# Altium schematic sheet PestAlert.SchDoc
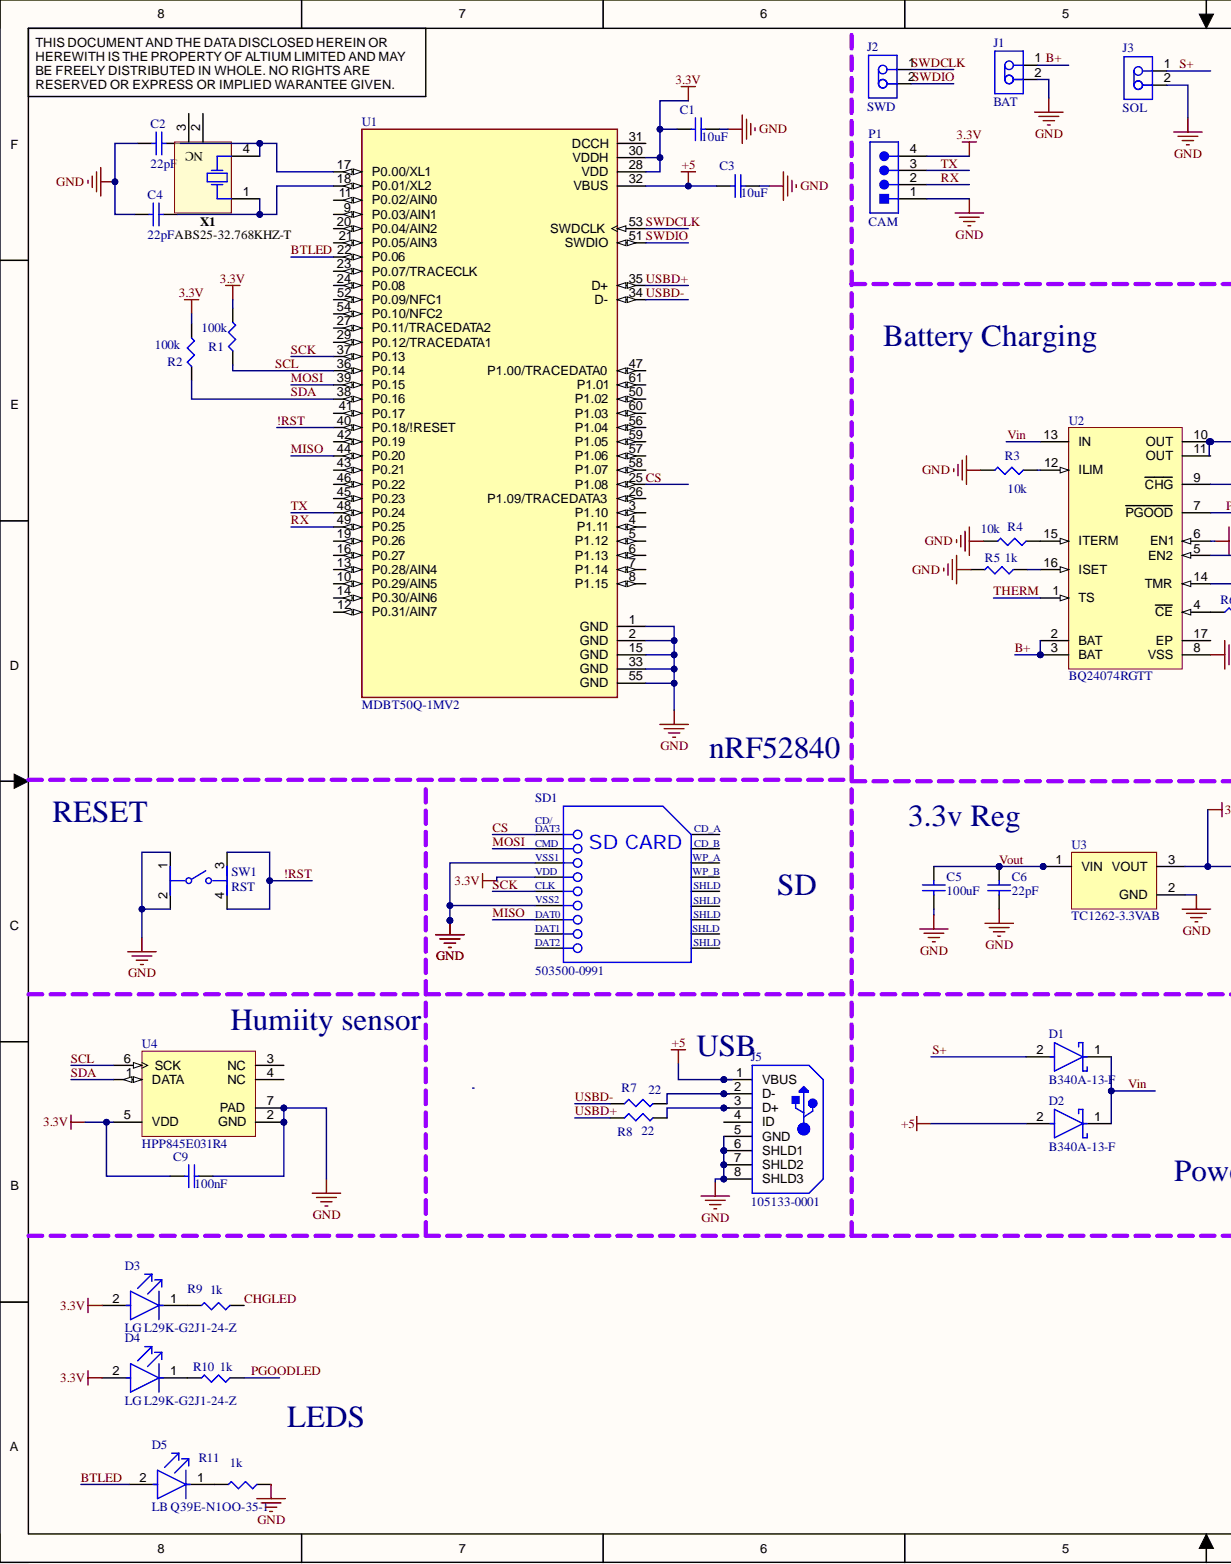
[diagram p0: PestAlert.SchDoc, left]
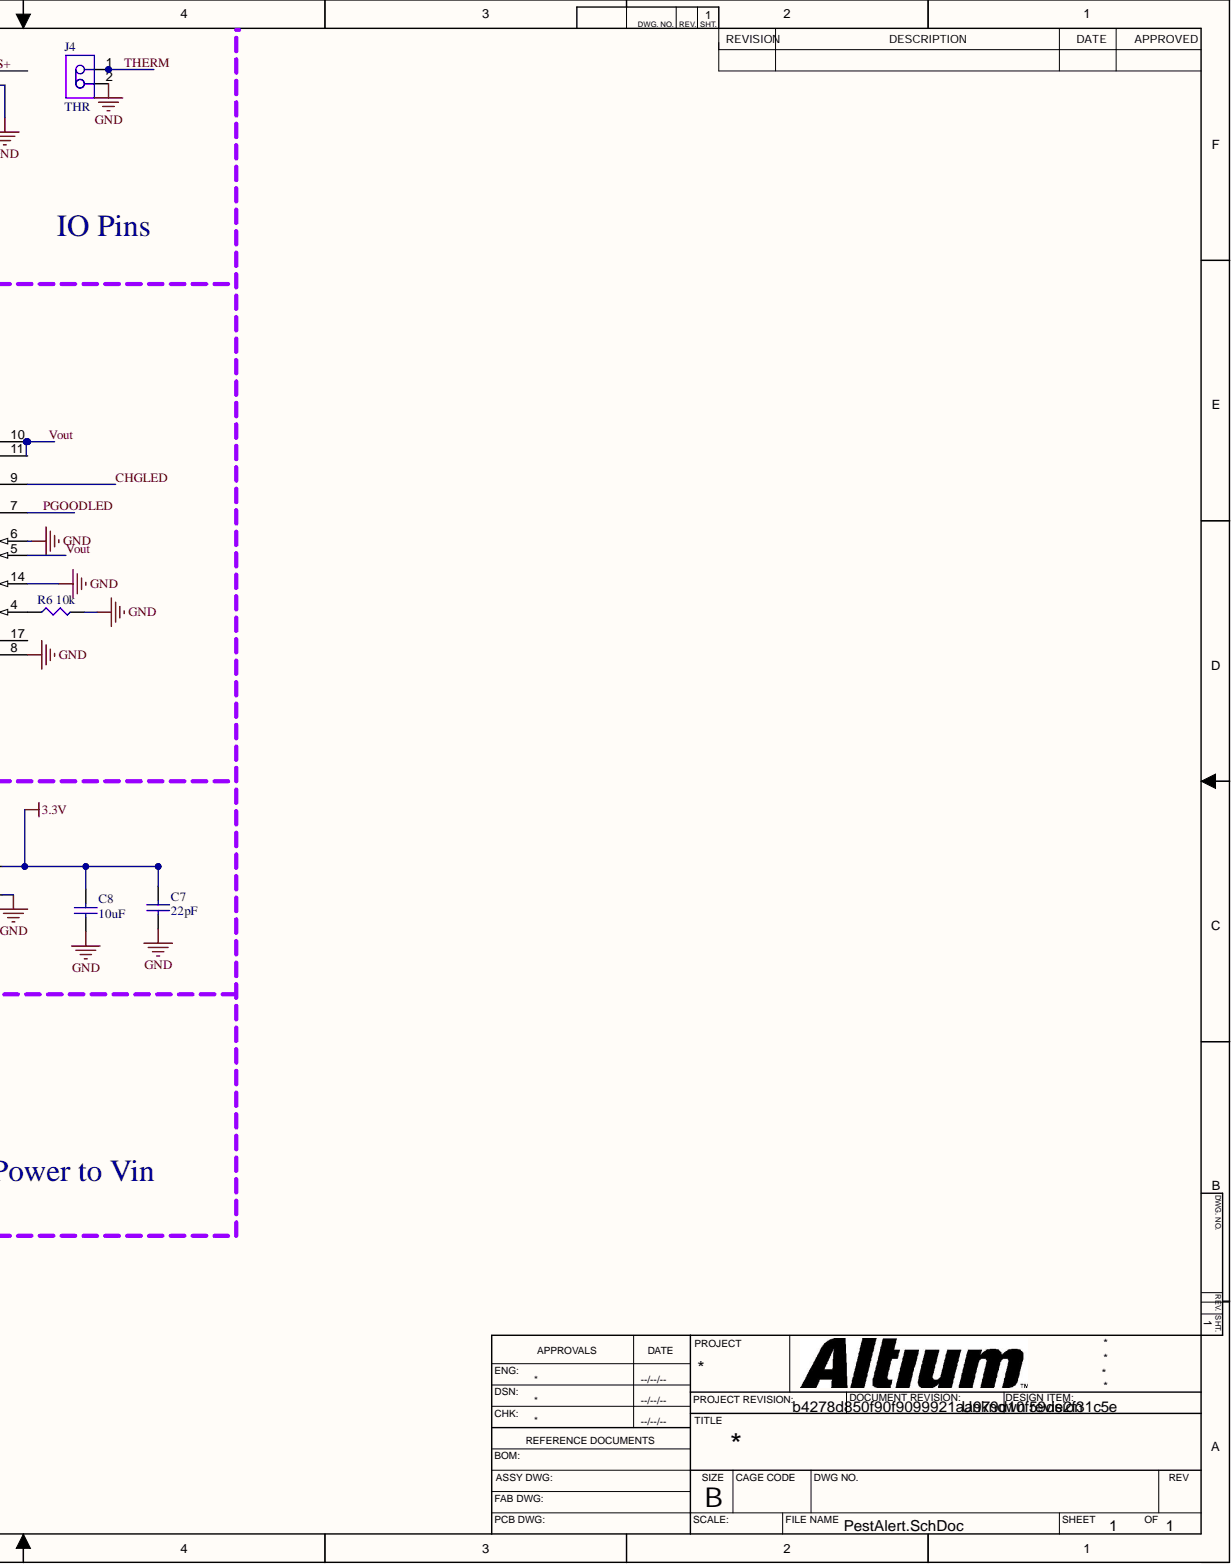
[diagram p1: PestAlert.SchDoc, right]

## Parts
C1  value 10uF  [C1206]  (hidden comment: Cap Semi)
C2  value 22pF  [C1206]  (hidden comment: Cap Semi)
C3  value 10uF  [C1206]  (hidden comment: Cap Semi)
C4  value 22pF  [C1206]  (hidden comment: Cap Semi)
C5  value 100uF  [C1206]  (hidden comment: Cap Semi)
C6  value 22pF  [C1206]  (hidden comment: Cap Semi)
C7  value 22pF  [C1206]  (hidden comment: Cap Semi)
C8  value 10uF  [C1206]  (hidden comment: Cap Semi)
C9  value 100nF  [C1206]  (hidden comment: Cap Semi)
D1  B340A-13-F  [FP-SMA-MFG]
D2  B340A-13-F  [FP-SMA-MFG]
D3  LG L29K-G2J1-24-Z  [FP-LG_L29K-MFG]
D4  LG L29K-G2J1-24-Z  [FP-LG_L29K-MFG]
D5  LB Q39E-N1OO-35-1  [FP-LB_Q39E-MFG]
J1  BAT  [JST-B2B-PH-K-S_V]
J2  SWD  [JST-B2B-PH-K-S_V]
J3  SOL  [JST-B2B-PH-K-S_V]
J4  THR  [JST-B2B-PH-K-S_V]
J5  105133-0001  Standard: USB  [MOLX-105133-0001_V]
P1  CAM  [JST-B4B-PH-K-S_V]
R1  100k  [SMD-1206-RES]
R2  100k  [SMD-1206-RES]
R3  10k  [SMD-1206-RES]
R4  10k  [SMD-1206-RES]
R5  1k  [SMD-1206-RES]
R6  10k  [SMD-1206-RES]
R7  22  [SMD-1206-RES]
R8  22  [SMD-1206-RES]
R9  1k  [SMD-1206-RES]
R10  1k  [SMD-1206-RES]
R11  1k  [SMD-1206-RES]
SD1  503500-0991  [MOLX-503500-0991_V]
SW1  RST  [FP-1825910-2-0]
U1  MDBT50Q-1MV2  [XCVR_MDBT50Q-1MV2]
U2  BQ24074RGTT  [RGT0016C_V]
U3  TC1262-3.3VAB  [TO-220-AB3]
U4  HPP845E031R4  [TECO-SON-6_V]
X1  ABS25-32.768KHZ-T  [ABRA-ABS25-4_V]

## Nets
3.3V: C1.1 C7.1 C8.1 C9.1 D3.2 D4.2 P1.4 R1.2 R2.2 SD1.4 U1.28(VDD) U1.30(VDDH) U3.3 U4.5(VDD)
+5: C3.1 D2.2 J5.1(VBUS) U1.32(VBUS)
GND: C1.2 C2.2 C3.2 C4.2 C5.2 C6.2 C7.2 C8.2 C9.2 J1.2 J3.2 J4.2 J5.5(GND) J5.6(SHLD1) J5.7(SHLD2) J5.8(SHLD3) P1.1 R3.2 R4.2 R5.2 R6.2 R11.1 SD1.3 SD1.6 SW1.1 SW1.2 U1.1(GND) U1.2(GND) U1.15(GND) U1.33(GND) U1.55(GND) U2.6(EN1) U2.8(VSS) U2.14(TMR) U3.2 U4.2(GND) U4.7(PAD)
!RST: SW1.3 SW1.4 U1.40(P0.18/!RESET)
B+: J1.1 U2.2(BAT) U2.3(BAT)
BTLED: D5.2 U1.22(P0.06)
CHGLED: R9.1 U2.9(CHG)
CS: SD1.1 U1.25(P1.08)
MISO: SD1.7 U1.44(P0.20)
MOSI: SD1.2(SD) U1.39(P0.15)
PGOODLED: R10.1 U2.7(PGOOD)
RX: P1.2 U1.49(P0.25)
S+: D1.2 J3.1
SCK: SD1.5 U1.37(P0.13)
SCL: R1.1 U1.36(P0.14) U4.6(SCK)
SDA: R2.1 U1.38(P0.16) U4.1(DATA)
SWDCLK: J2.1 U1.53(SWDCLK)
SWDIO: J2.2 U1.51(SWDIO)
THERM: J4.1 U2.1(TS)
TX: P1.3 U1.48(P0.24)
USBD+: R8.1 U1.35(D+)
USBD-: R7.1 U1.34(D-)
Vin: D1.1 D2.1 U2.13(IN)
Vout: C5.1 C6.1 U2.5(EN2) U2.10(OUT) U3.1
NetC2_1: C2.1 U1.17(P0.00/XL1) X1.4
NetC4_1: C4.1 U1.18(P0.01/XL2) X1.1
NetD3_1: D3.1 R9.2
NetD4_1: D4.1 R10.2
NetD5_1: D5.1 R11.2
NetJ5_2: J5.2(D-) R7.2
NetJ5_3: J5.3(D+) R8.2
NetR3_1: R3.1 U2.12(ILIM)
NetR4_1: R4.1 U2.15(ITERM)
NetR5_1: R5.1 U2.16(ISET)
NetR6_1: R6.1 U2.4(CE)

Not connected: J5 4(ID); SD1 8 9 10 11(CARD) 12 13 14 15 16 17 18; U1 3(P1.10) 4(P1.11) 5(P1.12) 6(P1.13) 7(P1.14) 8(P1.15) 9(P0.03/AIN1) 10(P0.29/AIN5) 11(P0.02/AIN0) 12(P0.31/AIN7) 13(P0.28/AIN4) 14(P0.30/AIN6) 16(P0.27) 19(P0.26) 20(P0.04/AIN2) 21(P0.05/AIN3) 23(P0.07/TRACECLK) 24(P0.08) 26(P1.09/TRACEDATA3) 27(P0.11/TRACEDATA2) 29(P0.12/TRACEDATA1) 31(DCCH) 41(P0.17) 42(P0.19) 43(P0.21) 45(P0.23) 46(P0.22) 47(P1.00/TRACEDATA0) 50(P1.02) 52(P0.09/NFC1) 54(P0.10/NFC2) 56(P1.04) 57(P1.06) 58(P1.07) 59(P1.05) 60(P1.03) 61(P1.01); U2 11(OUT) 17(EP); U4 3(NC) 4(NC); X1 2 3(NC)

## Library data of the parts
C1-C9 = Cap Semi: Capacitor (Semiconductor SIM Model); Component Kind: Standard; LatestRevisionDate: 29-May-2009; LatestRevisionNote: IPC-7351 Footprint Added.; Library Name: Miscellaneous Devices.IntLib; PackageDescription: Chip Capacitor; PackageReference: C1206; Published: 8-Jun-2000; Signal Integrity: Cap Semi; Simulation: CAP; Publisher: Altium Limited
D1 D2 = CMP-1667-00003-2: DIODE SCHOTTKY 40V 3A SMA; Capacitance: 250pF; Element Configuration: Single; Forward Voltage: 500mV; Max Forward Surge Current (Ifsm): 80A; Peak Reverse Current: 500uA; Polarity: Standard; Average Rectified Current: 3A; Case/Package: SMA; Component Kind: Standard; Contact Plating: Tin; Current: 3A; Current Rating: 3A; Forward Current: 3A; Height: 2.3mm; Lead Free: Lead Free; Length: 4.6mm; Library Name: Altium Content Vault; Max Operating Temperature: 125°C; Max Repetitive Reverse Voltage (Vrrm): 40V; Max Reverse Voltage (DC): 40V; Min Operating Temperature: -55°C; Mount: Surface Mount; Number of Pins: 2; Output Current: 3A; Package Quantity: 5000; Packaging: Tape and Reel; Peak Non-Repetitive Surge Current: 80A; Radiation Hardening: No; REACH SVHC: No SVHC; Termination: SMD/SMT; Voltage: 40V; Voltage Rating (DC): 40V; Weight: 0.002258oz; Width: 2.92mm
D3 D4 = CMP-62016-000024-1: LED GREEN DIFFUSED 0603 SMD; Dominant Wavelength: 570nm; Forward Current: 20mA; Forward Voltage: 2.2V; Lens Color: White; Lens Size: 0.8mm; Lens Style: Flat; Luminous Flux: 0.012lm; Luminous Intensity: 5.6mcd; Number of Elements: 1; Reverse Voltage: 12V; Test Current: 2mA; Viewing Angle: 160°; Case Code (Imperial): 0603; Case Code (Metric): 1608; Case/Package: 0603; Color: Green; Component Kind: Standard; Depth: 0.8mm; Height: 0.65mm; Illumination Color: Green; Length: 1.3mm; Lens Transparency: Diffused; Library Name: Altium Content Vault; Max Operating Temperature: 100°C; Min Operating Temperature: -40°C; Mount: Surface Mount; Number of LEDs: 1; Number of Pins: 2; Package Quantity: 5000; Packaging: Tape and Reel; Radiation Hardening: No; Width: 0.8mm
D5 = CMP-62016-000029-1: LED BLUE DIFFUSED 0603 SMD; Dominant Wavelength: 470nm; Forward Voltage: 2.85V; Lens Color: White; Lens Size: 0.8mm; Lens Style: Flat; Luminous Flux: 0.13lm; Luminous Intensity: 42mcd; Number of LEDs: 1; Test Current: 5mA; Viewing Angle: 130°; Case Code (Imperial): 0603; Case Code (Metric): 1608; Case/Package: 0603; Color: Blue; Component Kind: Standard; Height: 0.4mm; Length: 1.6mm; Lens Transparency: Diffused; Library Name: Altium Content Vault; Mount: Surface Mount; Number of Pins: 2; Packaging: Tape and Reel; Radiation Hardening: No; Width: 0.8mm
J1-J4 = CMP-2000-05162-1: Male Header, Pitch 2 mm, 1 x 2 Position, Height 6 mm, Tail Length 3.4 mm, -25 to 85 degC, RoHS, Bulk; Manufacturer: JST; No of Positions: 2; Pitch: 2mm; Style/Orientation: StraightHeader/Plug; Component Kind: Standard; Datasheet URL: http://datasheet.octopart.com/B2B-PH-K-S%28LF%29%28SN%29-JST-datasheet-8324864.pdf; Datasheet Version: Nov-08; Library Name: Altium Content Vault; Manufacturer URL: http://www.jst.com/home2.html; Termination: Crimp; Type: ShroudedHeader
J5 = CMP-2000-05829-1: Micro-USB B Receptacle, Vertical, -30 to 85 degC, 5-Pin SMD, RoHS, Tape and Reel; Brand: Molex; Connector Type: MicroUSBTypeBReceptacle; Current Rating: 1.8A; Number of Ports: 1; Package Version: Rev. C, 11/2015; Voltage - Max: 30V; Voltage Rating: 30VAC; Color: Black; Component Kind: Standard; Contact Material: CopperAlloy; Contact Plating: Gold; Datasheet URL: http://www.molex.com/webdocs/datasheets/pdf/en-us/1051330001_IO_CONNECTORS.pdf; Datasheet Version: 03/2016; Factory Pack Quantity: 6000; Flammability Rating: UL94V-0; Gender: Receptacle; Library Name: Altium Content Vault; Manufacturer: Molex; Manufacturer URL: http://www.molex.com/; Mounting Angle: Vertical; Mounting Style: SMD/SMT; Mounting Technology: SurfaceMount; Package Description: 5-Pin Surface Mount Device, Pitch 0.65 mm; Package Reference: 105133-0001; Package URL: http://www.molex.com/pdm_docs/sd/1051330001_sd.pdf; Packaging: Reel; PartNumber: 105133-0001; Product: MicroUSBTypeBConnectors; Product Category: USBConnectors; RoHS: Details; Series: 105133; Termination Style: SolderTail
P1 = CMP-2000-05165-1: Male Header, Pitch 2 mm, 1 x 4 Position, Height 6 mm, Tail Length 3.4 mm, -25 to 85 degC, RoHS, Bulk; Manufacturer: JST; No of Positions: 4; Pitch: 2mm; Style/Orientation: StraightHeader/Plug; Component Kind: Standard; Datasheet URL: http://datasheet.octopart.com/B4B-PH-K-S%28LF%29%28SN%29-JST-datasheet-10558886.pdf; Datasheet Version: Apr-09; Library Name: Altium Content Vault; Manufacturer URL: http://www.jst.com/home2.html; Termination: Crimp; Type: ShroudedHeader
R1 R2 = ERJ-8ENF1003V: RES SMD 100K OHM 1% 1/4W 1206; Manufacturer: Panasonic Electronic Components; @ qty: 1500; Factory Stock: 0; Minimum Quantity: 1; Power (Watts): 0.25W, 1/4W; Temperature Coefficient: ±100ppm/°C; Unit Price (USD): 0.00933; Component Kind: Standard; Composition: Thick Film; Datasheets: http://industrial.panasonic.com/www-cgi/jvcr13pz.cgi?E+PZ+3+AOA0002+ERJ8ENF1003V+7+WW; Digi-Key Part Number: P100KFCT-ND; Failure Rate: -; Features: Automotive AEC-Q200; Height - Seated (Max): 0.028" (0.70mm); Image: //media.digikey.com/Renders/Panasonic%20Renders/ERJ-1206%20Pkg.jpg; Library Name: Panasonic_ERJ_1206.IntLib; Number of Terminations: 2; Operating Temperature: -55°C ~ 155°C; Package / Case: 1206 (3216 Metric); Packaging: Cut Tape (CT); Part Number: ERJ-8ENF1003V; Part Status: Active; Quantity Available: 576605; Series: ERJ; Size / Dimension: 0.126" L x 0.063" W (3.20mm x 1.60mm); Supplier Device Package: 1206; Tolerance: ±1%; Value: 100k
R3 R4 R6 = ERJ-8ENF1002V: RES SMD 10K OHM 1% 1/4W 1206; Manufacturer: Panasonic Electronic Components; @ qty: 1500; Factory Stock: 0; Minimum Quantity: 1; Power (Watts): 0.25W, 1/4W; Temperature Coefficient: ±100ppm/°C; Unit Price (USD): 0.00933; Component Kind: Standard; Composition: Thick Film; Datasheets: http://industrial.panasonic.com/www-cgi/jvcr13pz.cgi?E+PZ+3+AOA0002+ERJ8ENF1002V+7+WW; Digi-Key Part Number: P10.0KFCT-ND; Failure Rate: -; Features: Automotive AEC-Q200; Height - Seated (Max): 0.028" (0.70mm); Image: //media.digikey.com/Renders/Panasonic%20Renders/ERJ-1206%20Pkg.jpg; Library Name: Panasonic_ERJ_1206.IntLib; Number of Terminations: 2; Operating Temperature: -55°C ~ 155°C; Package / Case: 1206 (3216 Metric); Packaging: Cut Tape (CT); Part Number: ERJ-8ENF1002V; Part Status: Active; Quantity Available: 1108398; Series: ERJ; Size / Dimension: 0.126" L x 0.063" W (3.20mm x 1.60mm); Supplier Device Package: 1206; Tolerance: ±1%; Value: 10k
R5 R9-R11 = ERJ-8ENF1001V: RES SMD 1K OHM 1% 1/4W 1206; Manufacturer: Panasonic Electronic Components; @ qty: 1500; Factory Stock: 0; Minimum Quantity: 1; Power (Watts): 0.25W, 1/4W; Temperature Coefficient: ±100ppm/°C; Unit Price (USD): 0.00933; Component Kind: Standard; Composition: Thick Film; Datasheets: http://industrial.panasonic.com/www-cgi/jvcr13pz.cgi?E+PZ+3+AOA0002+ERJ8ENF1001V+7+WW; Digi-Key Part Number: P1.00KFCT-ND; Failure Rate: -; Features: Automotive AEC-Q200; Height - Seated (Max): 0.028" (0.70mm); Image: //media.digikey.com/Renders/Panasonic%20Renders/ERJ-1206%20Pkg.jpg; Library Name: Panasonic_ERJ_1206.IntLib; Number of Terminations: 2; Operating Temperature: -55°C ~ 155°C; Package / Case: 1206 (3216 Metric); Packaging: Cut Tape (CT); Part Number: ERJ-8ENF1001V; Part Status: Active; Quantity Available: 607104; Series: ERJ; Size / Dimension: 0.126" L x 0.063" W (3.20mm x 1.60mm); Supplier Device Package: 1206; Tolerance: ±1%; Value: 1k
R7 R8 = ERJ-8ENF22R0V: RES SMD 22 OHM 1% 1/4W 1206; Manufacturer: Panasonic Electronic Components; @ qty: 1500; Factory Stock: 0; Minimum Quantity: 1; Power (Watts): 0.25W, 1/4W; Temperature Coefficient: ±100ppm/°C; Unit Price (USD): 0.00933; Component Kind: Standard; Composition: Thick Film; Datasheets: http://industrial.panasonic.com/www-cgi/jvcr13pz.cgi?E+PZ+3+AOA0002+ERJ8ENF22R0V+7+WW; Digi-Key Part Number: P22.0FCT-ND; Failure Rate: -; Features: Automotive AEC-Q200; Height - Seated (Max): 0.028" (0.70mm); Image: //media.digikey.com/Renders/Panasonic%20Renders/ERJ-1206%20Pkg.jpg; Library Name: Panasonic_ERJ_1206.IntLib; Number of Terminations: 2; Operating Temperature: -55°C ~ 155°C; Package / Case: 1206 (3216 Metric); Packaging: Cut Tape (CT); Part Number: ERJ-8ENF22R0V; Part Status: Active; Quantity Available: 174760; Series: ERJ; Size / Dimension: 0.126" L x 0.063" W (3.20mm x 1.60mm); Supplier Device Package: 1206; Tolerance: ±1%; Value: 22
SD1 = CMP-1676-00006-1: SD and Combo Memory Card Connector, 9 Position Surface Mount, -25 to 85 degC, RoHS, Tape and Reel; Case\Package: Axial; Max Supply Voltage: 5.5 V; Min Supply Voltage: 2.5 V; Nominal Supply Current: 3 mA; Output Current: 5 mA; Component Kind: Standard; ComponentLink1Description: Manufacturer URL; ComponentLink1URL: http://www.molex.com/; ComponentLink2Description: Datasheet; ComponentLink2URL: http://www.molex.com/pdm_docs/sd/5035000991_sd.pdf; DatasheetVersion: Rev. B, 02/2010; Height: 6.95 mm; Length: 5 mm; Library Name: Altium Content Vault; Max Operating Temperature: 85 degC; Min Operating Temperature: -25 degC; Mount: Through Hole; Package Quantity: 1500; PackageDescription: SD Card Connector, 9 Position Surface Mount, Length 28.6 mm, Height 3.1 mm; PackageReference: 503500-0991; Packaging: Bulk; Pins: 3; Radiation Hardening: No; REACH SVHC: Unknown; RoHSCompliant: true; Width: 4.8 mm
SW1 = CMP-03407-000004-1: SWITCH TACTILE SPST-NO 0.05A 24V; Manufacturer: TE; Manufacturer Part Number: 1825910-2; Contact Current Rating: 50mA; Contact Resistance: 100mΩ; Electromechanical Life: 100000Cycles; Operating Force: 160gf; Automotive: No; Category: Switch; Component Kind: Standard; ComponentLink2Description: Datasheet; ComponentLink2URL: https://www.te.com/commerce/DocumentDelivery/DDEController?Action=showdoc&DocId=Customer+Drawing%7F1825910%7FC10%7Fpdf%7FEnglish%7FENG_CD_1825910_C10.pdf%7F1825910-2; Device Class L1: Electromechanical; Device Class L2: Switches; Device Class L3: Tactile Switches; Digikey Description: SWITCH TACTILE SPST-NO 0.05A 24V; Height: 4.6mm; Lead Free: Yes; Library Name: Altium Content Vault; Mount: Through Hole; Octopart: https://octopart.com/1825910-2-te+connectivity-42270502; Package: 0; RoHS: TRUE; Temperature: -35°C to +85°C; Temperature Range High: +85°C; Temperature Range Low: -35°C; Throw Configuration: SPST-NO; Voltage Rating Dc: 24V
U1 = MDBT50Q-1MV2: MF: Raytac Corporation; Availability: Warning; Component Kind: Standard; Description: MDBT50Q-1MV2; Library Name: MDBT50Q-1MV2.IntLib; MP: MDBT50Q-1MV2; Package: None; Price: None; SnapEDA_Link: https://www.snapeda.com/parts/MDBT50Q-1MV2/Raytac%20Corporation/view-part/4593668/?ref=snap
U2 = CMP-0321-00218-2: USB-Friendly Li-Ion Battery Charger and Power-Path Management IC, 10.5 V OVP, 4.3 V, -40 to 85 degC, 16-pin QFN (RGT), Green (RoHS & no Sb/Br); Manufacturer: Texas Instruments; Lead Acid: N; SubFamily: Battery Charge Management; Vin(Min)(V): 4.3; Code_JEDEC: MO-220; Component Kind: Standard; ComponentLink1Description: Datasheet; ComponentLink1URL: http://www.ti.com/general/docs/lit/getliterature.tsp?genericPartNumber=BQ24074&fileType=pdf; DatasheetVersion: SLUS810G; Eco-Plan: Green (RoHS & no Sb/Br); Li-Ion: N; Li-Polymer: N; Library Name: Altium Content Vault; Mounting Technology: Surface Mount; NiCd: N; NiMH: N; Over Voltage Protection: N; PackageDescription: 16-Pin Plastic Quad Flat No-Lead, 3 x 3 mm Body, 0.5 mm Pitch, 1.7 x 1.7 mm Exposed Pad; PackageReference: RGT0016C; PackageVersion: revH, Oct-2011; PartNumber: BQ24074RGTT
U3 = CMP-0136-01006-1: 500mA Fixed Output CMOS LDO, 3-Pin TO-220, Extended Temperature; Component Kind: Standard; DatasheetVersion: revB, 2002; Library Name: Altium Content Vault; PackageDescription: 3-Lead Plastic Transistor Outline (AB) [TO-220]; PackageReference: TO-220-AB3; PackageVersion: revBB, Aug-2009
U4 = CMP-2100-03646-1: Digital Relative Humidity Sensor with Temperature Output, 1.5 to 3.6 V, -40 to 125 degC, 6-Pin SON, RoHS, Tape and Reel; Manufacturer: TE Connectivity; Component Kind: Standard; Contact Plating: Gold; Datasheet URL: http://www.te.com/commerce/DocumentDelivery/DDEController?Action=srchrtrv&DocNm=HPC199_6&DocType=Data+Sheet&DocLang=English; Datasheet Version: 42248.0; Lead Free: LeadFree; Library Name: Altium Content Vault; Manufacturer URL: http://www.te.com/; Max Operating Temperature: 125degC; Min Operating Temperature: -40degC; Mount: SurfaceMount; Package Description: 6-Pin Small Outline No-lead Package, Body 3 x 3 mm, Pitch 1 mm; Package Reference: SON-6; RoHSCompliant: true
X1 = CMP-2000-05037-1: Low Frequency Crystal, 32.768 KHz, -40 to 85 degC, 4-Pin SMD, RoHS, Tape and Reel; Brand: ABRACON; Case Height: 2.5mm; Case Length: 8mm; Case Width: 3.8mm; ESR: 50kOhms; Load Capacitance: 12.5pF; Tolerance: 20PPM; Component Kind: Standard; Datasheet URL: https://datasheet.ciiva.com/7998/1700987-7998663.pdf; Datasheet Version: May-11; Dimensions: 3.8mmWx8mmLx2.5mmH; Factory Pack Quantity: 1000; Frequency: 32.768kHz; Library Name: Altium Content Vault; Manufacturer: Abracon; Manufacturer URL: http://www.abracon.com/; Mounting Style: SMD/SMT; Operating Temperature Range: -40Cto+85C; Package / Case: 8mmx3.8mm; Package Description: 4-Pin Surface Mount Device, Body 8 x 3.8 mm, Pitch 5.5 mm; Package Reference: ABS25; Packaging: Reel; Product Category: Crystals; RoHS: Details; Series: ABS25; Termination Style: SMD/SMT; Type: LowFrequencyCrystal
